# Altium schematic sheet drv.SchDoc
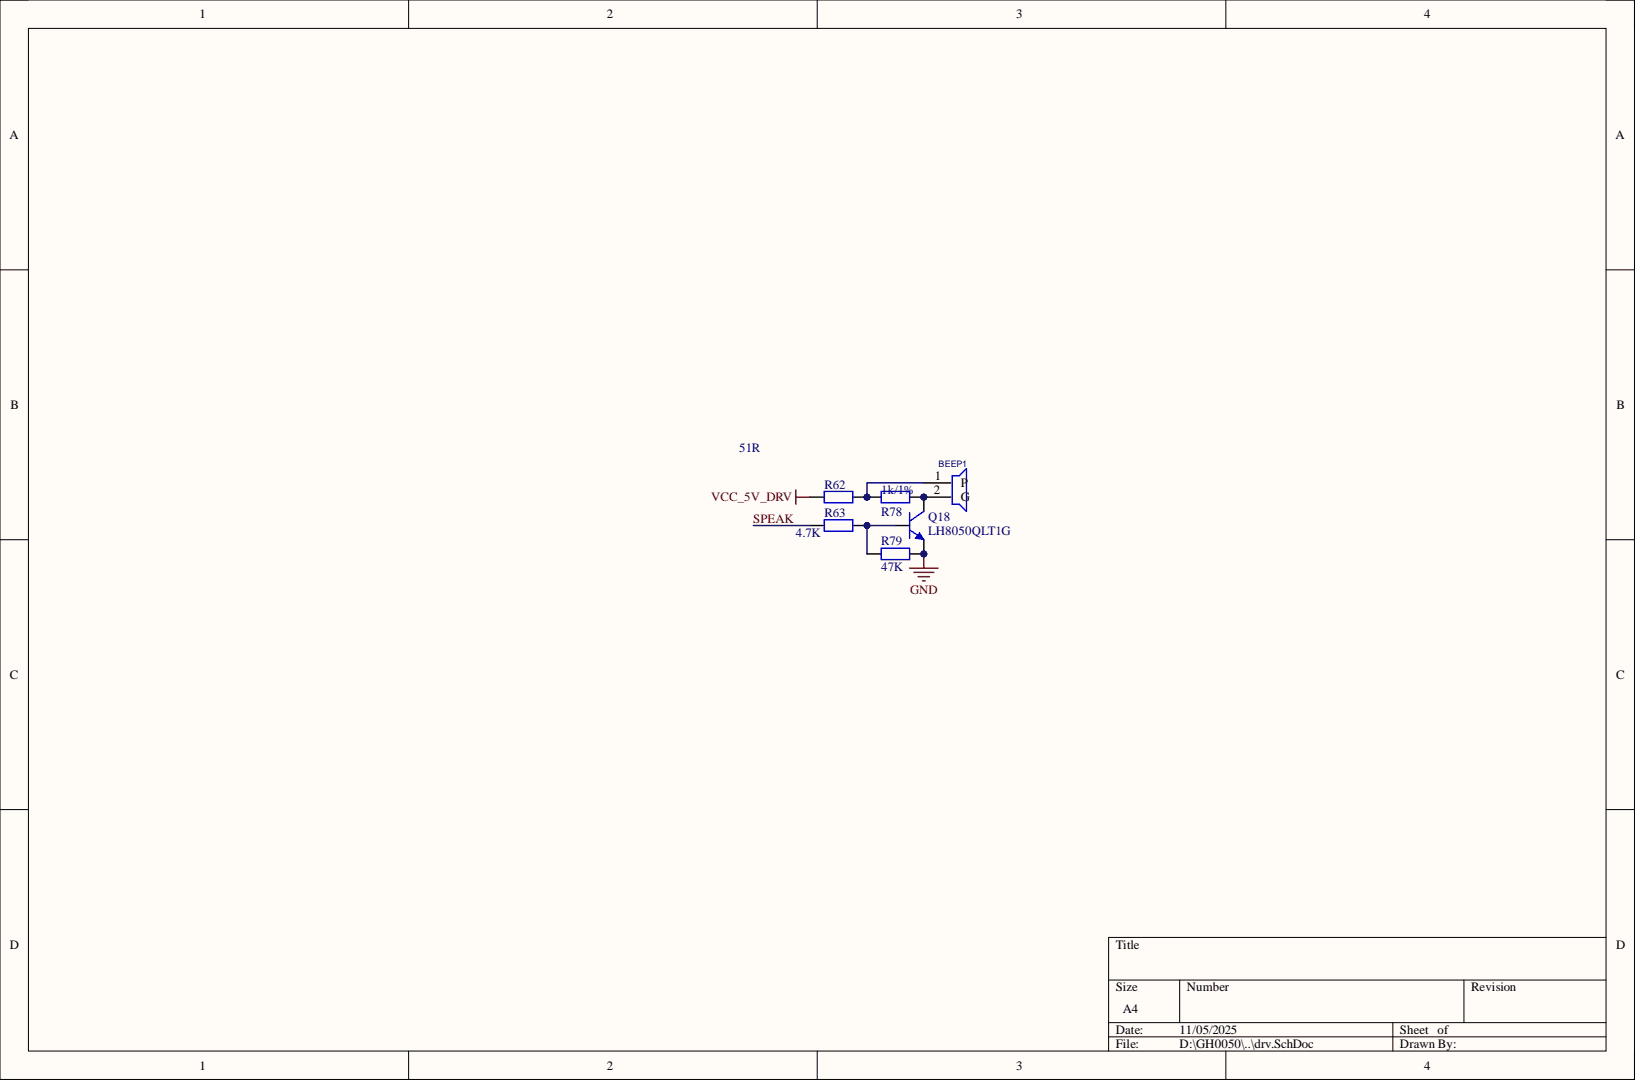
[diagram p0: drv.SchDoc]

## Parts
BEEP1  BEEP-9.5X5.5  [BEEP-9.5X5.5]  (value not drawn)  (no BOM)
Q18  LH8050QLT1G  [SOT-23]
R62  51R  [0603R]  (value not drawn)
R63  4.7K  [0603R]
R78  1k/1%  [0603R]
R79  47K  [0603R]

## Nets
GND: Q18.2 R79.2
VCC_5V_DRV: R62.2
SPEAK: R63.1
NetBEEP1_1: BEEP1.1 R62.1 R78.2
NetBEEP1_2: BEEP1.2 Q18.3 R78.1
NetQ18_1: Q18.1 R63.2 R79.1

## Library data of the parts
Q18 = NPN-1: NPN; Component Kind: Standard; Library Name: XiaoyI.SchLib
R62 R63 R78 R79 = RES0: 贴片电阻 5%; Component Kind: Standard; Library Name: ABB.SCHLIB
BEEP1 = BEEP-9.5X5.5: Library Name: MINI-V3.SchLib; PCB DECAL: BEEP-9.5X5.5
